# Altium schematic sheet µC.SchDoc
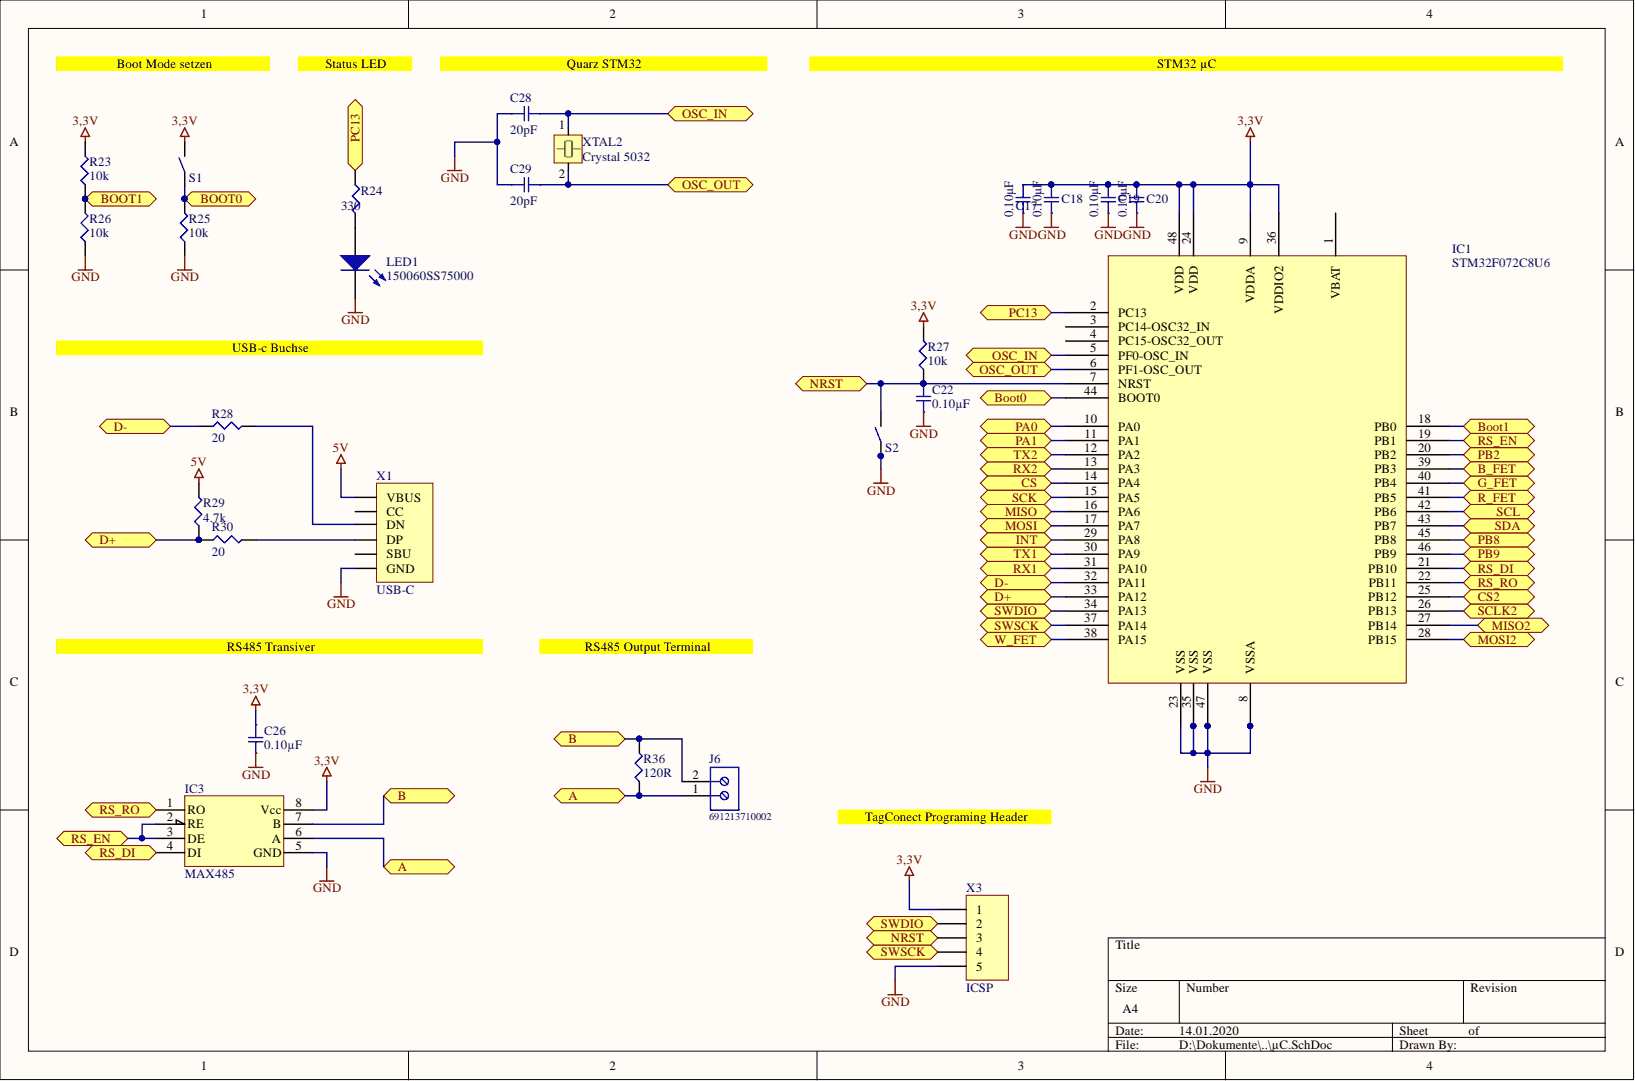
[diagram p0: µC.SchDoc]

## Parts
C17  0.10µF  [SMD-0603C]
C18  0.10µF  [SMD-0603C]
C19  0.10µF  [SMD-0603C]
C20  0.10µF  [SMD-0603C]
C22  0.10µF  [SMD-0603C]
C26  0.10µF  [SMD-0603C]
C28  20pF  [SMD-0603C]
C29  20pF  [SMD-0603C]
IC1  STM32F072C8U6  [LQFP-48]
IC3  MAX485  [D008_L]
J6  691213710002  [691213710002]
LED1  150060SS75000  [0603_A]
R23  10k  [SMD-0603-RES]
R24  330  [SMD-0603-RES]
R25  10k  [SMD-0603-RES]
R26  10k  [SMD-0603-RES]
R27  10k  [SMD-0603-RES]
R28  20  [SMD-0603-RES]
R29  4.7k  [SMD-0603-RES]
R30  20  [SMD-0603-RES]
R36  120R  [SMD-0603-RES]
S1  Button  [Button5050]  (value not drawn)
S2  Button  [Button5050]  (value not drawn)
X1  USB-C  [USB-C]
X3  ICSP  [TC2030-MCP-NL]
XTAL2  Crystal 5032  [5032]

## Ports
A, B, B_FET, BOOT0, Boot0, Boot1, BOOT1, CS, CS2, D+, D-, G_FET, INT, MISO, MISO2, MOSI, MOSI2, NRST, OSC_IN, OSC_OUT, PA0, PA1, PB2, PB8, PB9, PC13, R_FET, RS_DI, RS_EN, RS_RO, RX1, RX2, SCK, SCL, SCLK2, SDA, SWDIO, SWSCK, TX1, TX2, W_FET

## Nets
3,3V: C17.1 C18.1 C19.1 C20.1 C26.1 IC1.9(VDDA) IC1.24(VDD) IC1.36(VDDIO2) IC1.48(VDD) IC3.8(Vcc) R23.2 R27.2 S1.2 X3.1
5V: R29.2 X1.VBUS
GND: C17.2 C18.2 C19.2 C20.2 C22.2 C26.2 C28.1 C29.1 IC1.8(VSSA) IC1.23(VSS) IC1.35(VSS) IC1.47(VSS) IC3.5(GND) LED1.1 R25.1 R26.1 S2.1 X1.GND X3.5
A: IC3.6(A) J6.1 R36.1 ; port A
B: IC3.7(B) J6.2 R36.2 ; port B
B_FET: IC1.39(PB3) ; port B_FET
BOOT0: IC1.44(BOOT0) R25.2 S1.1 ; port BOOT0, port Boot0
BOOT1: IC1.18(PB0) R23.1 R26.2 ; port BOOT1, port Boot1
CS: IC1.14(PA4) ; port CS
CS2: IC1.25(PB12) ; port CS2
G_FET: IC1.40(PB4) ; port G_FET
INT: IC1.29(PA8) ; port INT
MISO: IC1.16(PA6) ; port MISO
MISO2: IC1.27(PB14) ; port MISO2
MOSI: IC1.17(PA7) ; port MOSI
MOSI2: IC1.28(PB15) ; port MOSI2
NRST: C22.1 IC1.7(NRST) R27.1 S2.2 X3.3 ; port NRST
OSC_IN: C28.2 IC1.5(PF0-OSC_IN) XTAL2.1 ; port OSC_IN
OSC_OUT: C29.2 IC1.6(PF1-OSC_OUT) XTAL2.2 ; port OSC_OUT
PA0: IC1.10(PA0) ; port PA0
PA1: IC1.11(PA1) ; port PA1
PB2: IC1.20(PB2) ; port PB2
PB8: IC1.45(PB8) ; port PB8
PB9: IC1.46(PB9) ; port PB9
PC13: IC1.2(PC13) R24.1 ; port PC13
R_FET: IC1.41(PB5) ; port R_FET
RS_DI: IC1.21(PB10) IC3.4(DI) ; port RS_DI
RS_EN: IC1.19(PB1) IC3.2(RE) IC3.3(DE) ; port RS_EN
RS_RO: IC1.22(PB11) IC3.1(RO) ; port RS_RO
RX1: IC1.31(PA10) ; port RX1
RX2: IC1.13(PA3) ; port RX2
SCK: IC1.15(PA5) ; port SCK
SCL: IC1.42(PB6) ; port SCL
SCLK2: IC1.26(PB13) ; port SCLK2
SDA: IC1.43(PB7) ; port SDA
SWDIO: IC1.34(PA13) X3.2 ; port SWDIO
SWSCK: IC1.37(PA14) X3.4 ; port SWSCK
TX1: IC1.30(PA9) ; port TX1
TX2: IC1.12(PA2) ; port TX2
W_FET: IC1.38(PA15) ; port W_FET
NetIC1_32: IC1.32(PA11) R28.1
NetIC1_33: IC1.33(PA12) R29.1 R30.1
NetLED1_2: LED1.2 R24.2
NetR28_2: R28.2 X1.DN
NetR30_2: R30.2 X1.DP

Not connected: IC1 1(VBAT) 3(PC14-OSC32_IN) 4(PC15-OSC32_OUT); X1 CC SBU

## Library data of the parts
C17-C20 C22 C26 C28 C29 = GCM188R71C104KA37J: CAP CER 0.1UF 16V X7R 0603; Manufacturer: Murata Electronics North America; @ qty: 0; Minimum Quantity: 1; Tolerance: ±10%; Unit Price (USD): 0.18; Applications: Automotive; Component Kind: Standard; Datasheets: http://www.murata.com/~/media/webrenewal/support/library/catalog/products/capacitor/mlcc/c03e.ashx; Digi-Key Part Number: 490-8015-1-ND; Factory Stock: 0; Features: -; Height - Seated (Max): -; Image: //media.digikey.com/Renders/Murata%20Renders/0603%28GCM188%29.jpg; Lead Spacing: -; Lead Style: -; Library Name: capacitor_murata_0603.IntLib; Mounting Type: Surface Mount, MLCC; Operating Temperature: -55°C ~ 125°C; Package / Case: 0603 (1608 Metric); Packaging: Cut Tape (CT); Part Number: GCM188R71C104KA37J; Part Status: Active; Quantity Available: 17844; Ratings: AEC-Q200; Series: GCM; Size / Dimension: 0.063" L x 0.031" W (1.60mm x 0.80mm); Temperature Coefficient: X7R; Thickness (Max): 0.035" (0.90mm); Voltage: 16V
  C17-C20 C22 C26: Value: 0.10µF
  C28 C29: Value: 20pF
J6 = 691213710002: Serie 213 - 5.00 mm Horizontal Entry Modular with RisingCage Clamp WR-TBL, 2 pin; Pin Number: 2; Pitch: 5.00 mm; Component Kind: Standard; ComponentLink1Description: Manufacturer; ComponentLink1URL: http://www.we-online.de; ComponentLink2Description: Datasheet; ComponentLink2URL: http://katalog.we-online.de/em/datasheet/6912137100xx.pdf; Library Name: Altium_TBL_RISING_CAGE_SYSTEM (rev18b).IntLib; Mounting Technology: THT; Operating Temperature: -40 to 105 degC; Packaging: Box; Part Number: 691213710002; Published Date: June 2018; Publisher: Wurth Elektronik
LED1 = 150060SS75000: SMD mono-color Chip LED, WL-SMCW, Super Red; Color: Super Red; Component Kind: Standard; ComponentLink1Description: Manufacturer URL; ComponentLink1URL: http://www.we-online.com; ComponentLink2Description: Datasheet; ComponentLink2URL: http://katalog.we-online.com/led/datasheet/150060SS75000.pdf; PackageReference: 0603_A; PartNumber: 150060SS75000; Library Name: WL-SMCW SMD chip LED top view mono-color waterclear.IntLib
R23 R25-R27 = ERJ-3EKF1002V: RES SMD 10K OHM 1% 1/10W 0603; Manufacturer: Panasonic Electronic Components; @ qty: 1500; Factory Stock: 0; Minimum Quantity: 1; Power (Watts): 0.1W, 1/10W; Temperature Coefficient: ±100ppm/°C; Unit Price (USD): 0.00379; Component Kind: Standard; Composition: Thick Film; Datasheets: http://industrial.panasonic.com/www-cgi/jvcr13pz.cgi?E+PZ+3+AOA0002+ERJ3EKF1002V+7+WW; Digi-Key Part Number: P10.0KHCT-ND; Failure Rate: -; Features: Automotive AEC-Q200; Height - Seated (Max): 0.022" (0.55mm); Image: //media.digikey.com/Photos/Panasonic%20Photos/MFG_ERJ-P6W%20Series.jpg; Library Name: Panasonic_ERJ_0603.IntLib; Number of Terminations: 2; Operating Temperature: -55°C ~ 155°C; Package / Case: 0603 (1608 Metric); Packaging: Cut Tape (CT); Part Number: ERJ-3EKF1002V; Part Status: Active; Quantity Available: 6703141; Series: ERJ; Size / Dimension: 0.063" L x 0.031" W (1.60mm x 0.80mm); Supplier Device Package: 0603; Tolerance: ±1%; Value: 10k
R24 = ERJ-3EKF3300V: RES SMD 330 OHM 1% 1/10W 0603; Manufacturer: Panasonic Electronic Components; @ qty: 1500; Factory Stock: 0; Minimum Quantity: 1; Power (Watts): 0.1W, 1/10W; Temperature Coefficient: ±100ppm/°C; Unit Price (USD): 0.00379; Component Kind: Standard; Composition: Thick Film; Datasheets: http://industrial.panasonic.com/www-cgi/jvcr13pz.cgi?E+PZ+3+AOA0002+ERJ3EKF3300V+7+WW; Digi-Key Part Number: P330HCT-ND; Failure Rate: -; Features: Automotive AEC-Q200; Height - Seated (Max): 0.022" (0.55mm); Image: //media.digikey.com/Photos/Panasonic%20Photos/MFG_ERJ-P6W%20Series.jpg; Library Name: Panasonic_ERJ_0603.IntLib; Number of Terminations: 2; Operating Temperature: -55°C ~ 155°C; Package / Case: 0603 (1608 Metric); Packaging: Cut Tape (CT); Part Number: ERJ-3EKF3300V; Part Status: Active; Quantity Available: 670984; Series: ERJ; Size / Dimension: 0.063" L x 0.031" W (1.60mm x 0.80mm); Supplier Device Package: 0603; Tolerance: ±1%; Value: 330
R28 R30 = ERJ-3EKF20R0V: RES SMD 20 OHM 1% 1/10W 0603; Manufacturer: Panasonic Electronic Components; @ qty: 1500; Factory Stock: 0; Minimum Quantity: 1; Power (Watts): 0.1W, 1/10W; Temperature Coefficient: ±100ppm/°C; Unit Price (USD): 0.00379; Component Kind: Standard; Composition: Thick Film; Datasheets: http://industrial.panasonic.com/www-cgi/jvcr13pz.cgi?E+PZ+3+AOA0002+ERJ3EKF20R0V+7+WW; Digi-Key Part Number: P20.0HCT-ND; Failure Rate: -; Features: Automotive AEC-Q200; Height - Seated (Max): 0.022" (0.55mm); Image: //media.digikey.com/Photos/Panasonic%20Photos/MFG_ERJ-P6W%20Series.jpg; Library Name: Panasonic_ERJ_0603.IntLib; Number of Terminations: 2; Operating Temperature: -55°C ~ 155°C; Package / Case: 0603 (1608 Metric); Packaging: Cut Tape (CT); Part Number: ERJ-3EKF20R0V; Part Status: Active; Quantity Available: 92419; Series: ERJ; Size / Dimension: 0.063" L x 0.031" W (1.60mm x 0.80mm); Supplier Device Package: 0603; Tolerance: ±1%; Value: 20
R29 R36 = ERJ-3EKF4701V: RES SMD 4.7K OHM 1% 1/10W 0603; Manufacturer: Panasonic Electronic Components; @ qty: 1500; Factory Stock: 0; Minimum Quantity: 1; Power (Watts): 0.1W, 1/10W; Temperature Coefficient: ±100ppm/°C; Unit Price (USD): 0.00379; Component Kind: Standard; Composition: Thick Film; Datasheets: http://industrial.panasonic.com/www-cgi/jvcr13pz.cgi?E+PZ+3+AOA0002+ERJ3EKF4701V+7+WW; Digi-Key Part Number: P4.70KHCT-ND; Failure Rate: -; Features: Automotive AEC-Q200; Height - Seated (Max): 0.022" (0.55mm); Image: //media.digikey.com/Photos/Panasonic%20Photos/MFG_ERJ-P6W%20Series.jpg; Library Name: Panasonic_ERJ_0603.IntLib; Number of Terminations: 2; Operating Temperature: -55°C ~ 155°C; Package / Case: 0603 (1608 Metric); Packaging: Cut Tape (CT); Part Number: ERJ-3EKF4701V; Part Status: Active; Quantity Available: 999380; Series: ERJ; Size / Dimension: 0.063" L x 0.031" W (1.60mm x 0.80mm); Supplier Device Package: 0603; Tolerance: ±1%
  R29: Value: 4.7k
  R36: Value: 120R
S1 S2 = Button: 6.1x3.7x2.5mm; Component Kind: Standard; Library Name: MyLibs.SchLib
X1 = USB-C 16P: Component Kind: Standard; Library Name: MyLibs.SchLib
XTAL2 = 5032: Component Kind: Standard; Library Name: MyLibs.SchLib
IC1 = STM32F072C8U6: Component Kind: Standard; Library Name: MyLibs.SchLib
IC3 = MAX485: Component Kind: Standard; Library Name: MyLibs.SchLib
X3 = ICSP: Component Kind: Standard; Library Name: TC2030.IntLib
